# Altium schematic sheet OpenRing_MCU.SchDoc
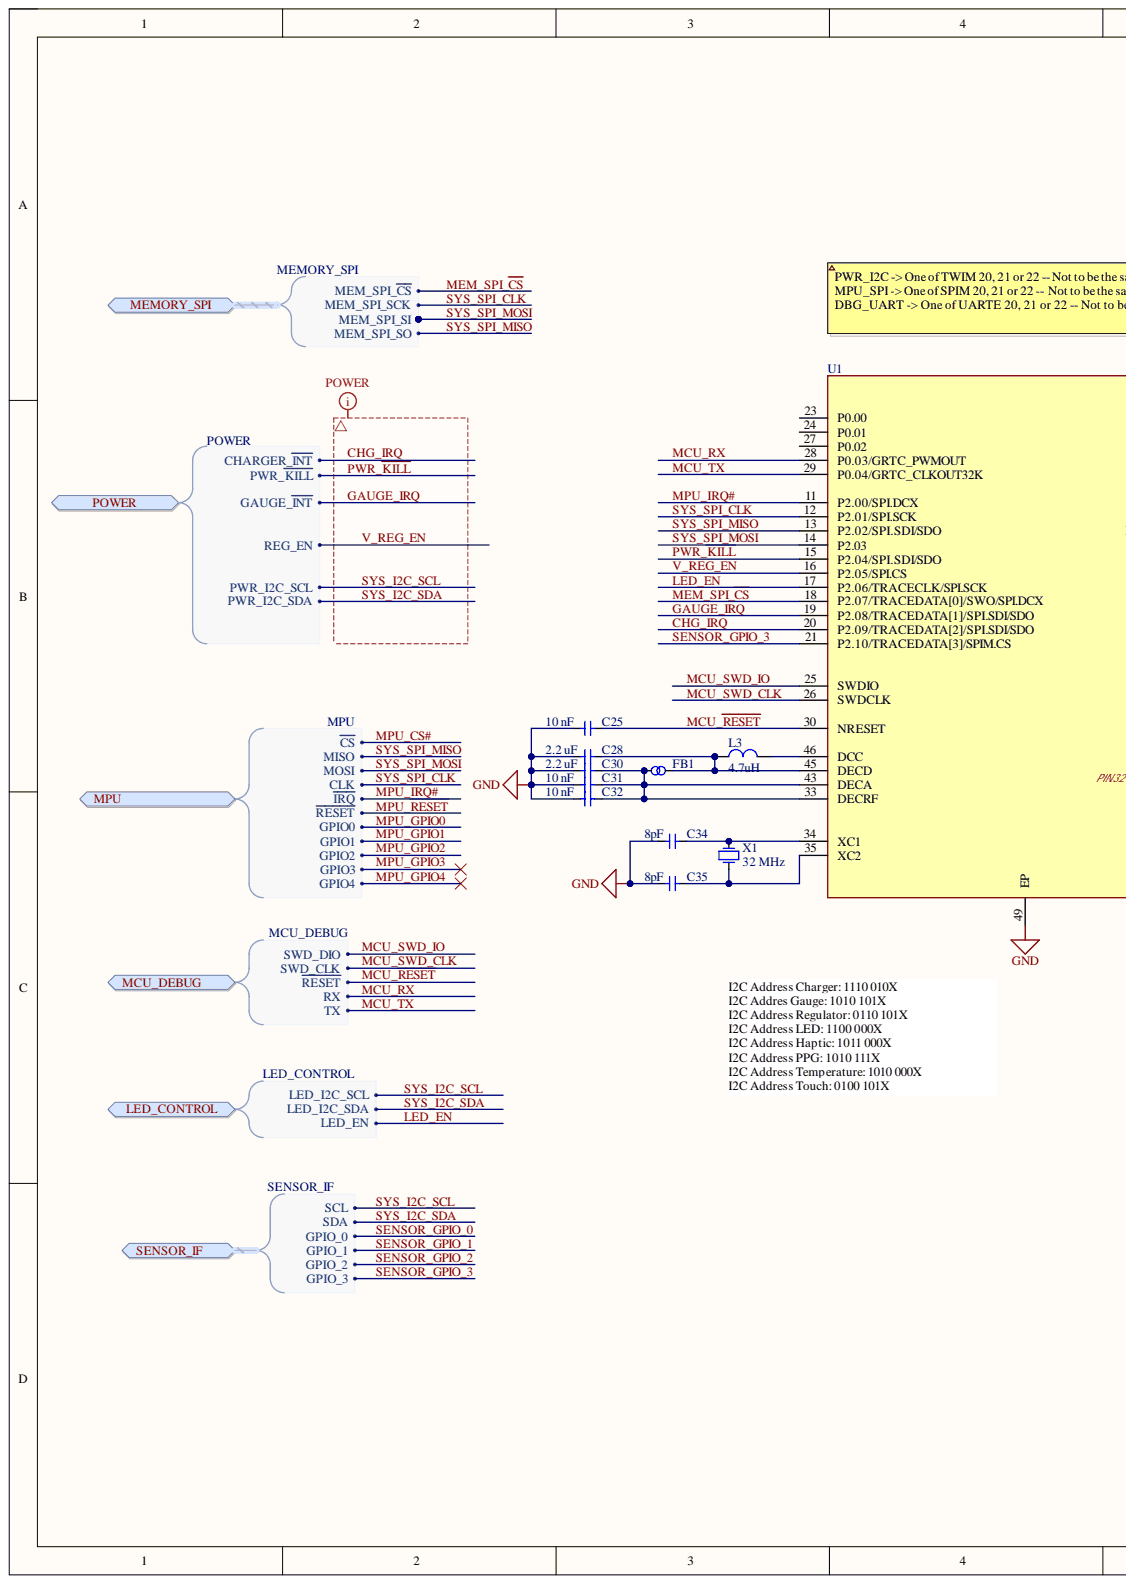
[diagram p0: OpenRing_MCU.SchDoc, left]
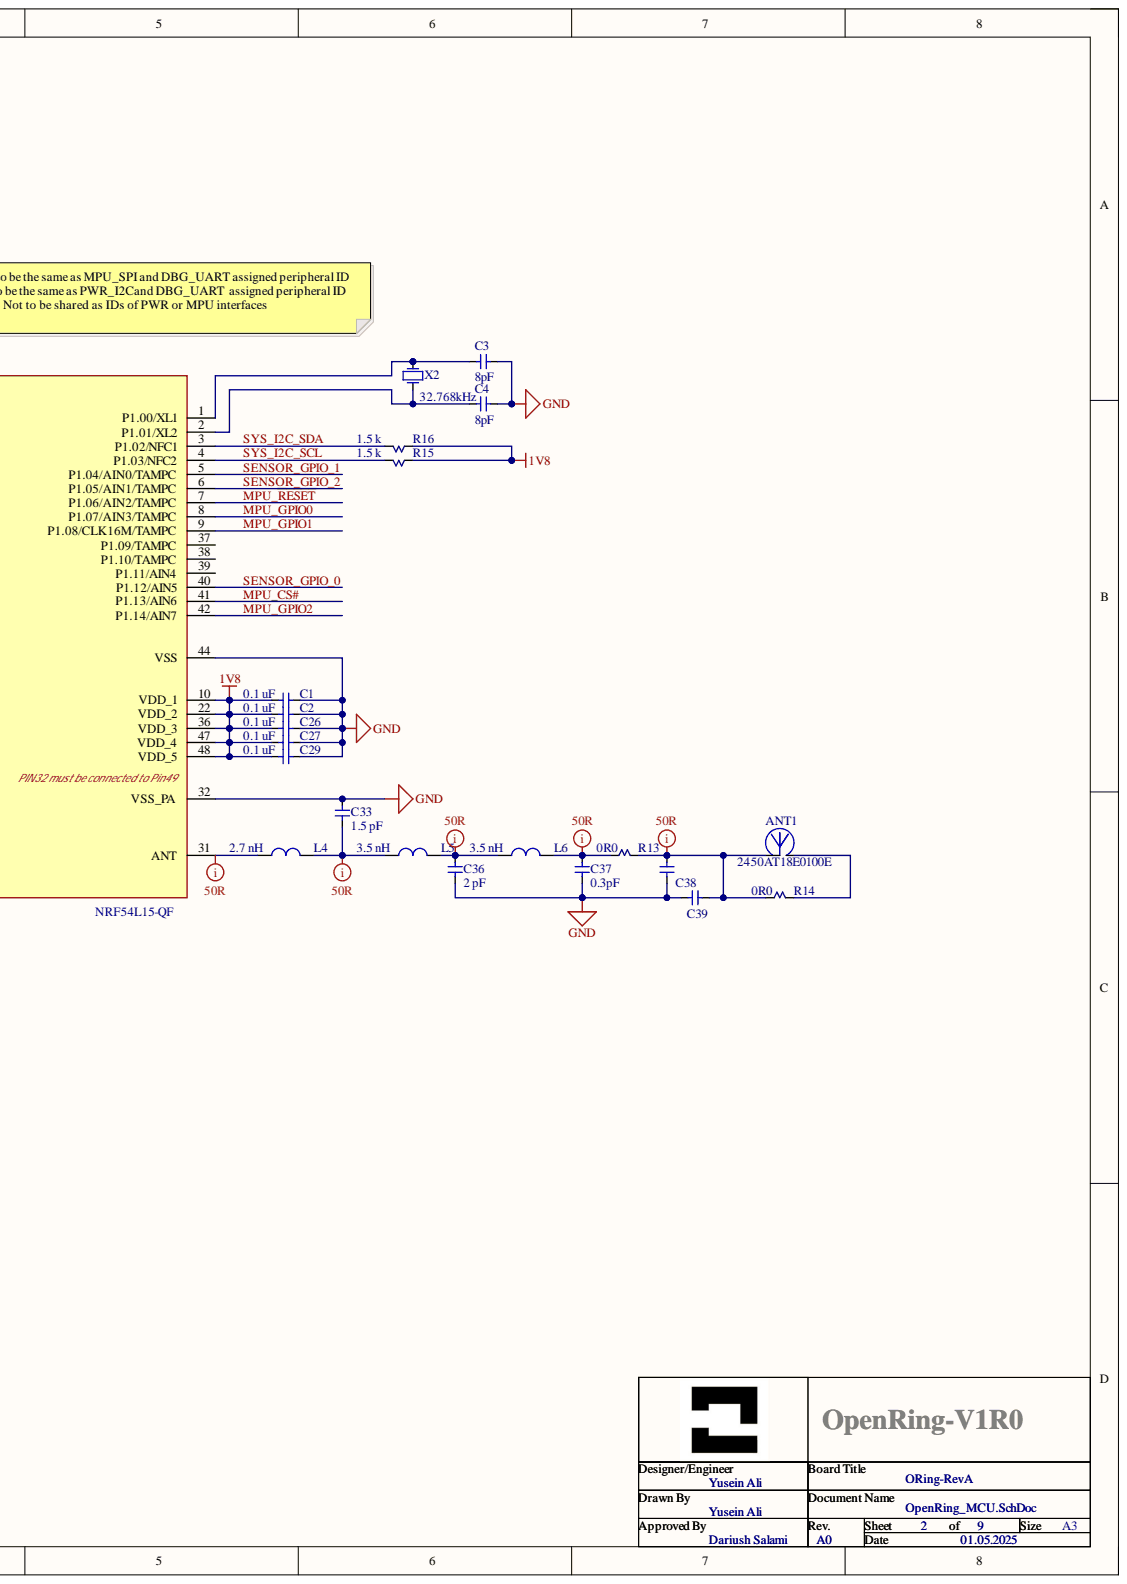
[diagram p1: OpenRing_MCU.SchDoc, right]

## Parts
ANT1  2450AT18E0100E  [ANTC3216X130N]
C1  0.1 uF  [CAPC1005X05N]
C2  0.1 uF  [CAPC1005X05N]
C3  8pF  [CAPC1005X05N]
C4  8pF  [CAPC1005X05N]
C25  10 nF  [CAPC1005X05N]
C26  0.1 uF  [CAPC1005X05N]
C27  0.1 uF  [CAPC1005X05N]
C28  2.2 uF  [CAPC1005X05N]
C29  0.1 uF  [CAPC1005X05N]
C30  2.2 uF  [CAPC1005X05N]
C31  10 nF  [CAPC1005X05N]
C32  10 nF  [CAPC1005X05N]
C33  1.5 pF  [CAPC1005X05N]
C34  8pF  [CAPC1005X05N]
C35  8pF  [CAPC1005X05N]
C36  2 pF  [CAPC1005X05N]
C37  0.3pF  [INDC1005X06N]
C38  NC  [CAPC1005X05N]  (value not drawn)
C39  NC  [CAPC1005X05N]  (value not drawn)
FB1  BLM15AG121SN1D  [INDC1005X06N]  (value not drawn)
L3  4.7uH  [INDC1005X06N]
L4  2.7 nH  [INDC1005X06N]
L5  3.5 nH  [INDC1005X06N]
L6  3.5 nH  [INDC1005X06N]
R13  0R0  [RESC1005X04N]
R14  0R0  [RESC1005X04N]
R15  1.5 k  [RESC1005X04N]
R16  1.5 k  [RESC1005X04N]
U1  NRF54L15-QF  [QFN40P600X600X95-49N]
X1  32 MHz  [FP-ABM11W-MFG]
X2  32.768kHz  [Abracon_ABS6_2012X06L]

## Ports
LED_CONTROL, LED_CONTROL.LED_EN, LED_CONTROL.LED_I2C_SCL, LED_CONTROL.LED_I2C_SDA, MCU_DEBUG, MCU_DEBUG.RX, MCU_DEBUG.SWD_CLK, MCU_DEBUG.SWD_DIO, MCU_DEBUG.TX, MCU_DEBUG.~{RESET}, MEMORY_SPI, MEMORY_SPI.MEM_SPI_SCK, MEMORY_SPI.MEM_SPI_SI, MEMORY_SPI.MEM_SPI_SO, MEMORY_SPI.MEM_SPI_~{CS}, MPU, MPU.CLK, MPU.GPIO0, MPU.GPIO1, MPU.GPIO2, MPU.GPIO3, MPU.GPIO4, MPU.MISO, MPU.MOSI, MPU.~{CS}, MPU.~{IRQ}, MPU.~{RESET}, POWER, POWER.CHARGER_~{INT}, POWER.GAUGE_~{INT}, POWER.PWR_I2C_SCL, POWER.PWR_I2C_SDA, POWER.PWR_~{KILL}, POWER.REG_EN, SENSOR_IF, SENSOR_IF.GPIO_0, SENSOR_IF.GPIO_1, SENSOR_IF.GPIO_2, SENSOR_IF.GPIO_3, SENSOR_IF.SCL, SENSOR_IF.SDA

## Nets
1V8: C1.1 C2.1 C26.1 C27.1 C29.1 R15.1 R16.1 U1.10(VDD_1) U1.22(VDD_2) U1.36(VDD_3) U1.47(VDD_4) U1.48(VDD_5)
GND: C1.2 C2.2 C3.2 C4.1 C25.1 C26.2 C27.2 C28.1 C29.2 C30.1 C31.1 C32.1 C33.2 C34.1 C35.1 C36.1 C37.1 C38.1 C39.2 U1.32(VSS_PA) U1.44(VSS) U1.49(EP)
CHG_IRQ: U1.20(P2.09/TRACEDATA[2]/SPI.SDI/SDO) ; port POWER
GAUGE_IRQ: U1.19(P2.08/TRACEDATA[1]/SPI.SDI/SDO) ; port POWER
LED_EN: U1.17(P2.06/TRACECLK/SPI.SCK) ; port LED_CONTROL
MCU_~{RESET}: C25.2 U1.30(NRESET) ; port MCU_DEBUG
MCU_RX: U1.28(P0.03/GRTC_PWMOUT) ; port MCU_DEBUG
MCU_SWD_CLK: U1.26(SWDCLK) ; port MCU_DEBUG
MCU_SWD_IO: U1.25(SWDIO) ; port MCU_DEBUG
MCU_TX: U1.29(P0.04/GRTC_CLKOUT32K) ; port MCU_DEBUG
MEM_SPI_~{CS}: U1.18(P2.07/TRACEDATA[0]/SWO/SPI.DCX) ; port MEMORY_SPI
MPU_CS#: U1.41(P1.13/AIN6) ; port MPU
MPU_GPIO0: U1.8(P1.07/AIN3/TAMPC) ; port MPU
MPU_GPIO1: U1.9(P1.08/CLK16M/TAMPC) ; port MPU
MPU_GPIO2: U1.42(P1.14/AIN7) ; port MPU
MPU_IRQ#: U1.11(P2.00/SPI.DCX) ; port MPU
MPU_~{RESET}: U1.7(P1.06/AIN2/TAMPC) ; port MPU
PWR_~{KILL}: U1.15(P2.04/SPI.SDI/SDO) ; port POWER
SENSOR_GPIO_0: U1.40(P1.12/AIN5) ; port SENSOR_IF
SENSOR_GPIO_1: U1.5(P1.04/AIN0/TAMPC) ; port SENSOR_IF
SENSOR_GPIO_2: U1.6(P1.05/AIN1/TAMPC) ; port SENSOR_IF
SENSOR_GPIO_3: U1.21(P2.10/TRACEDATA[3]/SPIM.CS) ; port SENSOR_IF
SYS_I2C_SCL: R15.2 U1.4(P1.03/NFC2) ; port LED_CONTROL, port POWER, port SENSOR_IF
SYS_I2C_SDA: R16.2 U1.3(P1.02/NFC1) ; port LED_CONTROL, port POWER, port SENSOR_IF
SYS_SPI_CLK: U1.12(P2.01/SPI.SCK) ; port MEMORY_SPI, port MPU
SYS_SPI_MISO: U1.13(P2.02/SPI.SDI/SDO) ; port MEMORY_SPI, port MPU
SYS_SPI_MOSI: U1.14(P2.03) ; port MEMORY_SPI, port MPU
V_REG_EN: U1.16(P2.05/SPI.CS) ; port POWER
NetANT1_1: ANT1.1 C38.2 C39.1 R13.2 R14.1
NetANT1_2: ANT1.2 R14.2
NetC3_1: C3.1 U1.1(P1.00/XL1) X2.2
NetC4_2: C4.2 U1.2(P1.01/XL2) X2.1
NetC28_2: C28.2 FB1.2 L3.1 U1.45(DECD)
NetC30_2: C30.2 C31.2 C32.2 FB1.1 U1.33(DECRF) U1.43(DECA)
NetC33_1: C33.1 L4.2 L5.1
NetC34_2: C34.2 U1.34(XC1) X1.3
NetC35_2: C35.2 U1.35(XC2) X1.1
NetC36_2: C36.2 L5.2 L6.1
NetC37_2: C37.2 L6.2 R13.1
NetL3_2: L3.2 U1.46(DCC)
NetL4_1: L4.1 U1.31(ANT)
MPU_GPIO3: ; port MPU
MPU_GPIO4: ; port MPU

Not connected: U1 23(P0.00) 24(P0.01) 27(P0.02) 37(P1.09/TAMPC) 38(P1.10/TAMPC) 39(P1.11/AIN4)

## Library data of the parts
ANT1 = 2450AT18E0100E: 7 Inch Reel / Mini 2.45Ghz Chip Antenna; Manufacturer: Johanson; Checked: TRUE; Category: RF Antennas; Component Kind: Standard; ComponentLink1Description: https://datasheet.ciiva.com/24048/antenna-2450at18e0100-253355-24048542.pdf?src-supplier=Mouser; ComponentLink1URL: https://datasheet.ciiva.com/24048/antenna-2450at18e0100-253355-24048542.pdf?src-supplier=Mouser; Library Name: RFComponents.SchLib; Manufacturer Part Number: 2450AT18E0100E; Pricing: 1=0.69, 10=0.624, 50=0.624, 100=0.479, 1000=0.303, 10000=0.245 (USD); RoHS: Yes; Stock: 4431; Supplier: Mouser; Supplier 1: Mouser; Supplier Part Number: 609-2450AT18E0100E; Supplier Part Number 1: 609-2450AT18E0100E
C1 C2 C26 C27 C29 = GRM155R61C104KA88D: CAP CER 0.1UF 16V X5R 0402; Brand: Murata Electronics; Capacitance - nF: 100 nF; Capacitance - pF: 100000 pF; Tolerance: 10 %; Type: Chip Multilayer Ceramic Capacitor for General Purpose; Voltage Rating DC: 16 VDC; Capacitance: 0.1 uF; Case Code - in: 0402; Case Code - mm: 1005; Category: Multilayer Ceramic Capacitors MLCC - SMD/SMT; Component Kind: Standard; ComponentLink1Description: http://www.mouser.com/datasheet/2/281/murata_03052018_GRM_Series_1-1310166.pdf; ComponentLink1URL: http://www.mouser.com/datasheet/2/281/murata_03052018_GRM_Series_1-1310166.pdf; Dielectric: X5R; Height: 0.5 mm; Length: 1 mm; Manufacturer: Murata Electronics; Manufacturer Part Number: GRM155R61C104KA88D; Maximum Operating Temperature: + 85 C; Minimum Operating Temperature: - 55 C; Minimum Order Quantity: 1; Package / Case: 0402 (1005 metric); Packaging: Cut Tape; Pricing: 1=0.082, 10=0.026, 100=0.011, 500=0.008, 1000=0.007, 2500=0.006, 5000=0.005, 10000=0.004 (EUR); Product: General Type MLCCs; Reeling: True; RoHS: RoHS Compliant; RoHS - Mouser: Y; Series: GRM; Standard Pack Qty: 10000; Stock: 0; Supplier 1: Mouser; Supplier 2: Digikey; Supplier 3: Digikey; Supplier Part Number 1: 81-GRM155R61C104KA8D; Supplier Part Number 2: 490-5415-1-ND; Supplier Part Number 3: 490-5415-6-ND; Termination: Standard; Termination Style: SMD/SMT; Value: 0.1 uF; Width: 0.5 mm; Library Name: OpenRingComponents.IntLib
C3 C4 C34 C35 = GRM1555C1H8R0BA01D: Multilayer Ceramic Capacitors MLCC - SMD/SMT 0402 8.0pF 50volts C0G +/-0.05pF; Manufacturer: Murata; Voltage Rating: 50V; Case Code (Imperial): 0402; Case Code (Metric): 1005; Case/Package: 0402; Category: Ceramic Capacitors; Component Kind: Standard; ComponentLink1Description: https://datasheet.ciiva.com/18184/getdatasheetpartid-319021-18184500.pdf?src-supplier=Verical; ComponentLink1URL: https://datasheet.ciiva.com/18184/getdatasheetpartid-319021-18184500.pdf?src-supplier=Verical; ComponentLink2Description: https://datasheet.ciiva.com/6263/grm1555c1h820ga01-01-6263780.pdf?src-supplier=Digi-Key; ComponentLink2URL: https://datasheet.ciiva.com/6263/grm1555c1h820ga01-01-6263780.pdf?src-supplier=Digi-Key; ComponentLink3Description: https://datasheet.ciiva.com/5432/product-5432205.pdf?src-supplier=Mouser; ComponentLink3URL: https://datasheet.ciiva.com/5432/product-5432205.pdf?src-supplier=Mouser; ComponentLink4Description: https://datasheet.ciiva.com/11610/product-11610151.pdf?src-supplier=Avnet; ComponentLink4URL: https://datasheet.ciiva.com/11610/product-11610151.pdf?src-supplier=Avnet; ComponentLink5Description: https://datasheet.ciiva.com/16495/c02e-16495287.pdf?src-supplier=Future+Electronics; ComponentLink5URL: https://datasheet.ciiva.com/16495/c02e-16495287.pdf?src-supplier=Future+Electronics; ComponentLink6Description: https://datasheet.ciiva.com/5442/product-5442458.pdf?src-supplier=Mouser; ComponentLink6URL: https://datasheet.ciiva.com/5442/product-5442458.pdf?src-supplier=Mouser; Construction: Flat; Depth: 0.5mm; Dielectric: C0G; Height: 0.5mm; Lead Free: Lead Free; Length: 1mm; Manufacturer Part Number: GRM1555C1H8R0BA01D; Max Operating Temperature: 125°C; Min Operating Temperature: -55°C; Mounting Technology: Surface Mount; Package Quantity: 1; Packaging: Tape and Reel; Pricing: 1=0,1, 10=0,051, 50=0,0278, 100=0,0227, 500=0,01624, 1000=0,01276, 2500=0,0116, 5000=0,01067 (USD); Radiation Hardening: No; RoHS: Yes; RoHS Compliant: Yes; Series: GRM; Stock: 671988; Supplier: Digi-Key; Supplier 1: Mouser; Supplier 2: Digikey; Supplier 3: Digikey; Supplier Part Number: 490-8230-1-ND; Supplier Part Number 1: 81-GRM1555C1H8R0BA1D; Supplier Part Number 2: 490-8230-1-ND; Supplier Part Number 3: 490-8230-6-ND; Termination: SMD/SMT; Thickness: 0.022inch; Value: 8pF; Voltage Rating (DC): 50V; Width: 0.02inch; Library Name: OpenRingComponents.IntLib
C25 C31 C32 = GRM155R71H103KA88D: CAP CER 10000PF 50V X7R 0402; Manufacturer: Murata Electronics North America; Tolerance: ±10%; Applications: General Purpose; Capacitance: 10000pF; Category: Capacitors; Component Kind: Standard; ComponentLink1Description: http://www.murata.com/~/media/webrenewal/support/library/catalog/products/capacitor/mlcc/c02e.pdf; ComponentLink1URL: http://www.murata.com/~/media/webrenewal/support/library/catalog/products/capacitor/mlcc/c02e.pdf; Features: -; Height - Seated (Max): -; Lead Spacing: -; Lead Style: -; Manufacturer Part Number: GRM155R71H103KA88D; Mounting Type: Surface Mount, MLCC; Operating Temperature: -55°C ~ 125°C; Package / Case: 0402 (1005 Metric); Packaging: Digi-Reel®; Part Status: Active; Pricing 1: 1=0.11, 50=0.0056, 100=0.0047, 250=0.00384, 500=0.0033, 1000=0.00256, 5000=0.00213 (GBP); Ratings: -; RoHS: RoHS Compliant; Signal Integrity: Model Name; Size / Dimension: 0.039" L x 0.020" W (1.00mm x 0.50mm); Stock 1: 7217649; Supplier 1: Digi-Key; Supplier Part Number 1: 490-4516-6-ND; Temperature Coefficient: X7R; Thickness (Max): 0.022" (0.55mm); Value: 10 nF; Voltage - Rated: 50V; Library Name: OpenRingComponents.IntLib
C28 C30 = GRM155R61A225KE95D: CAP CER 2.2UF 10V X5R 0402; Manufacturer: Murata Electronics North America; Capacitance: 2.2µF; Tolerance: ±10%; Applications: General Purpose; Category: Capacitors; Component Kind: Standard; ComponentLink1Description: http://www.murata.com/~/media/webrenewal/support/library/catalog/products/capacitor/mlcc/c02e.ashx; ComponentLink1URL: http://www.murata.com/~/media/webrenewal/support/library/catalog/products/capacitor/mlcc/c02e.ashx; Features: Derating Recommended; Height - Seated (Max): -; Lead Spacing: -; Lead Style: -; Manufacturer Part Number: GRM155R61A225KE95D; Mounting Type: Surface Mount, MLCC; Operating Temperature: -55°C ~ 85°C; Package / Case: 0402 (1005 Metric); Packaging: Digi-Reel®; Part Status: Active; Pricing 1: 1=0.1, 10=0.06, 50=0.0326, 100=0.0275, 250=0.02252, 500=0.01926, 1000=0.015, 5000=0.0125 (USD); Ratings: -; RoHS: RoHS Compliant; Signal Integrity: Model Name; Size / Dimension: 0.039" L x 0.020" W (1.00mm x 0.50mm); Stock 1: 370562; Supplier 1: Digi-Key; Supplier Part Number 1: 490-10451-6-ND; Temperature Coefficient: X5R; Thickness (Max): 0.022" (0.55mm); Value: 2.2 uF; Voltage - Rated: 10V; Library Name: OpenRingComponents.IntLib
C33 = GJM1555C1H1R5BB01D: Multilayer Ceramic Capacitor, 1.5 pF, 50 V, ï¿½ 0.1pF, C0G (NP0), 0402 [1005 Metric]; Manufacturer: Murata; Material: 4241ConductorMaterial; Category: Ceramic Capacitors; Component Kind: Standard; ComponentLink1Description: https://datasheet.ciiva.com/8030/1700191-8030107.pdf?src-supplier=Element14; ComponentLink1URL: https://datasheet.ciiva.com/8030/1700191-8030107.pdf?src-supplier=Element14; ComponentLink2Description: https://datasheet.ciiva.com/26843/getdatasheetpartid-698463-26843693.pdf?src-supplier=Verical; ComponentLink2URL: https://datasheet.ciiva.com/26843/getdatasheetpartid-698463-26843693.pdf?src-supplier=Verical; ComponentLink3Description: https://datasheet.ciiva.com/29542/partnumbering-e-01-29542725.pdf?src-supplier=Digikey; ComponentLink3URL: https://datasheet.ciiva.com/29542/partnumbering-e-01-29542725.pdf?src-supplier=Digikey; ComponentLink4Description: https://datasheet.ciiva.com/29542/partnumbering-e-01-29542726.pdf?src-supplier=Digikey; ComponentLink4URL: https://datasheet.ciiva.com/29542/partnumbering-e-01-29542726.pdf?src-supplier=Digikey; ComponentLink5Description: https://datasheet.ciiva.com/16440/l0005e-16440473.pdf?src-supplier=Future+Electronics; ComponentLink5URL: https://datasheet.ciiva.com/16440/l0005e-16440473.pdf?src-supplier=Future+Electronics; Lead Free: 5697LeadFree; Manufacturer Part Number: GJM1555C1H1R5BB01D; Pricing: 1=0.1, 10=0.05, 50=0.05, 100=0.03, 1000=0.022, 10000=0.011 (USD); RoHS: Yes; Stock: 9670; Supplier: Mouser; Supplier 1: Mouser; Supplier 2: Digikey; Supplier 3: Digikey; Supplier Part Number: 81-GJM1555C1H1R5BB1D; Supplier Part Number 1: 81-GJM1555C1H1R5BB1D; Supplier Part Number 2: 490-8087-1-ND; Supplier Part Number 3: 490-8087-6-ND; Value: 1.5 pF; Library Name: OpenRingComponents.IntLib
C36 = GJM1555C1H2R0WB01D: 0402 2 pF 50V ±0.05 pF Tolerance C0G SMT Multilayer Ceramic Capacitor; Manufacturer: Murata; Material: 4241ConductorMaterial; Temperature Coefficient: 30ppm/°C; Category: Ceramic Capacitors; Component Kind: Standard; ComponentLink1Description: https://datasheet.ciiva.com/26843/getdatasheetpartid-724043-26843695.pdf?src-supplier=Verical; ComponentLink1URL: https://datasheet.ciiva.com/26843/getdatasheetpartid-724043-26843695.pdf?src-supplier=Verical; Lead Free: 5697LeadFree; Library Name: Passives.SchLib; Manufacturer Part Number: GJM1555C1H2R0WB01D; Pricing: 1=0.14, 10=0.077, 50=0.077, 100=0.047, 1000=0.032, 10000=0.019 (USD); RoHS: Yes; Stock: 97187; Supplier: Mouser; Supplier 1: Mouser; Supplier 2: Digikey; Supplier 3: Digikey; Supplier Part Number: 81-GJM1555C1H2R0WB1D; Supplier Part Number 1: 81-GJM1555C1H2R0WB1D; Supplier Part Number 2: 490-11205-1-ND; Supplier Part Number 3: 490-11205-6-ND; Value: 2 pF
C37 = GJM1555C1HR30WB01D: 0402 0.3 pF 50V ±0.05 pF Tolerance C0G SMT Multilayer Ceramic Capacitor; Manufacturer: Murata; Material: 4241ConductorMaterial; Temperature Coefficient: 30ppm/°C; Case Code (Imperial): 0402; Case Code (Metric): 1005; Case/Package: 0402; Category: Ceramic Capacitors; Component Kind: Standard; ComponentLink1Description: https://datasheet.ciiva.com/11617/product-11617574.pdf?src-supplier=Avnet; ComponentLink1URL: https://datasheet.ciiva.com/11617/product-11617574.pdf?src-supplier=Avnet; ComponentLink2Description: https://datasheet.ciiva.com/169/partnumbering-e-01-169995.pdf?src-supplier=Digikey; ComponentLink2URL: https://datasheet.ciiva.com/169/partnumbering-e-01-169995.pdf?src-supplier=Digikey; Construction: Flat; Depth: 0.5mm; Dielectric: C0G; Height: 0.5mm; Lead Free: Lead Free; Length: 1mm; Manufacturer Part Number: GJM1555C1HR30WB01D; Max Operating Temperature: 125°C; Min Operating Temperature: -55°C; Mounting Technology: Surface Mount; Package Quantity: 10000; Packaging: Tape and Reel; Pricing: 1=0.14, 10=0.077, 50=0.077, 100=0.047, 1000=0.031, 10000=0.021 (USD); Radiation Hardening: No; RoHS: Yes; RoHS Compliant: Yes; Series: GJM; Stock: 24305; Supplier: Mouser; Supplier 1: Mouser; Supplier 2: Digikey; Supplier 3: Digikey; Supplier Part Number: 81-GJM1555C1HR30WB1D; Supplier Part Number 1: 81-GJM1555C1HR30WB1D; Supplier Part Number 2: 490-11248-1-ND; Supplier Part Number 3: 490-11248-6-ND; Termination: SMD/SMT; Thickness: 0.022inch; Value: 0.3pF; Voltage Rating: 50V; Voltage Rating (DC): 50V; Width: 0.02inch; Library Name: OpenRingComponents.IntLib
C38 C39 = CAPACITOR: Component Kind: Standard; Library Name: OpenRingComponents.IntLib
FB1 = BLM15AG121SN1D: Ferrite Beads Multi-Layer 120Ohm 25% 100MHz 550mA 190mOhm DCR 0402 Paper T/R; Manufacturer: Murata; Manufacturer Series: BLM15A; Channels: 1; DC Current: 500mA; DC Resistance (DCR): 190mR; DC Resistance-Max: 250mR; Impedance: 120R; Material: Steel,Ferrite; Shielding: Unshielded; Case Code (Imperial): 0402; Case Code (Metric): 1005; Case/Package: 0402; Category: Ferrite Beads and Chips; Component Kind: Standard; Core Material: Ferrite; Current Rating: 550mA; Depth: 0.55mm; ESR: 190mR; Frequency: 100MHz; Height: 0.5mm; Lead Free: Lead Free; Length: 1mm; Manufacturer Part Number: BLM15AG121SN1D; Max Current Rating: 550mA; Max DC Current: 500mA; Max Operating Temperature: 125°C; Military Standard: Not; Min Operating Temperature: -55°C; Number of Circuits: 1; Number of Lines: 1; Package Quantity: 1; Packaging: Tape and Reel; Pins: 2; Pricing: 1=0.1, 10=0.043, 50=0.034, 100=0.031, 1000=0.021, 10000=0.013 (USD); Radiation Hardening: No; Reach SVHC Compliant: No; RMS Current (Irms): 550mA; RoHS: Yes; RoHS Compliant: Yes; Series: BLM15AG_SN; Stock: 120691; Supplier: Mouser; Supplier 1: Mouser; Supplier 2: Digikey; Supplier 3: Digikey; Supplier Part Number: 81-BLM15AG121SN1D; Supplier Part Number 1: 81-BLM15AG121SN1D; Supplier Part Number 2: 490-1005-1-ND; Supplier Part Number 3: 490-1005-6-ND; Terminal Finish: Tin; Termination: SMD/SMT; Test Frequency: 100MHz; Tolerance: 25%; Width: 0.5mm; Library Name: OpenRingComponents.IntLib
L3 = LQM18FN4R7M00D: Inductor Power Chip Shielded Multi-Layer 4.7uH 20% 1MHz Ferrite 0.08A 0.78Ohm DCR 0603 T/R; Manufacturer: Murata; Current Rating: 80mA; DC Resistance (DCR): 600mR; Self Resonant Frequency: 50MHz; Case Code (Imperial): 0603; Case Code (Metric): 1608; Case/Package: 0603; Category: Fixed Inductors; Component Kind: Standard; ComponentLink1Description: https://datasheet.ciiva.com/30489/0900766b812560c4-30489024.pdf?src-supplier=Rs; ComponentLink1URL: https://datasheet.ciiva.com/30489/0900766b812560c4-30489024.pdf?src-supplier=Rs; ComponentLink2Description: https://datasheet.ciiva.com/1039/l0075s0097lqm18f-1039394.pdf?src-supplier=Digikey; ComponentLink2URL: https://datasheet.ciiva.com/1039/l0075s0097lqm18f-1039394.pdf?src-supplier=Digikey; ComponentLink3Description: https://datasheet.ciiva.com/1039/l0075s0097lqm18f-1039393.pdf?src-supplier=Digikey; ComponentLink3URL: https://datasheet.ciiva.com/1039/l0075s0097lqm18f-1039393.pdf?src-supplier=Digikey; ComponentLink4Description: https://datasheet.ciiva.com/1039/l0075s0097lqm18f-1039395.pdf?src-supplier=Digikey; ComponentLink4URL: https://datasheet.ciiva.com/1039/l0075s0097lqm18f-1039395.pdf?src-supplier=Digikey; ComponentLink5Description: https://datasheet.ciiva.com/3082/l0075s0097lqm18f-3082197.pdf?src-supplier=Avnet; ComponentLink5URL: https://datasheet.ciiva.com/3082/l0075s0097lqm18f-3082197.pdf?src-supplier=Avnet; ComponentLink6Description: https://datasheet.ciiva.com/16390/l0075s0097lqm18f-16390346.pdf?src-supplier=Future+Electronics; ComponentLink6URL: https://datasheet.ciiva.com/16390/l0075s0097lqm18f-16390346.pdf?src-supplier=Future+Electronics; Core Material: Ferrite; DC Current: 80mA; Depth: 0.9mm; Height: 0.8mm; Height - Seated (Max): 0.035inch; Lead Free: Lead Free; Length: 1.6mm; Library Name: Passives.SchLib; Manufacturer Part Number: LQM18FN4R7M00D; Max Current Rating: 80mA; Max DC Current: 80mA; Max Operating Temperature: 125°C; Military Standard: Not; Min Operating Temperature: -55°C; Mounting Technology: Surface Mount; Package Quantity: 1; Packaging: Tape and Reel; Pricing: 1=0.13, 10=0.11, 50=0.11, 100=0.091, 1000=0.075, 10000=0.06 (USD); RoHS: Yes; RoHS Compliant: Yes; Saturation Current: 80mA; Series: LQM18F; Shielding: Shielded; Stock: 677; Supplier: Mouser; Supplier 1: Mouser; Supplier 2: Digikey; Supplier 3: Digikey; Supplier Part Number: 81-LQM18FN4R7M00D; Supplier Part Number 1: 81-LQM18FN4R7M00D; Supplier Part Number 2: 490-4024-1-ND; Supplier Part Number 3: 490-4024-6-ND; Termination: SMD/SMT; Test Frequency: 1MHz; Tolerance: 20%; Value: 4.7uH; Weight: 7.1E-05oz; Width: 0.031inch
L4 = LQG15WH2N7B02D: Inductor Chip 2.7nH 0.1nH Tolerance 2-Pin 0402 Paper T/R; Manufacturer: Murata; Category: Fixed Inductors; Component Kind: Standard; Manufacturer Part Number: LQG15WH2N7B02D; Pricing: 1=0.2, 10=0.161, 50=0.141, 100=0.133, 1000=0.109, 10000=0.088 (USD); RoHS: Yes; Stock: 6000; Supplier: Mouser; Supplier 1: Mouser; Supplier 2: Digikey; Supplier 3: Digikey; Supplier Part Number: 81-LQG15WH2N7B02D; Supplier Part Number 1: 81-LQG15WH2N7B02D; Supplier Part Number 2: 490-LQG15WH2N7B02DDKR-ND; Supplier Part Number 3: 490-LQG15WH2N7B02DCT-ND; Value: 2.7 nH; Library Name: OpenRingComponents.IntLib
L5 L6 = LQG15WH3N5B02D: Inductor Chip 3.5nH 0.1nH Tolerance 2-Pin 0402 Paper T/R; Manufacturer: Murata; Category: Fixed Inductors; Component Kind: Standard; Manufacturer Part Number: LQG15WH3N5B02D; Pricing: 1=0.24, 10=0.187, 50=0.187, 100=0.146, 1000=0.113, 10000=0.09 (USD); RoHS: Yes; Stock: 9594; Supplier: Mouser; Supplier 1: Mouser; Supplier 2: Farnell; Supplier 3: Farnell; Supplier Part Number: 81-LQG15WH3N5B02D; Supplier Part Number 1: 81-LQG15WH3N5B02D; Supplier Part Number 2: 3639288; Supplier Part Number 3: 3639288RL; Value: 3.5 nH; Library Name: OpenRingComponents.IntLib
R13 R14 = ERJ-2GE0R00X: RES SMD 0.0OHM JUMPER 1/10W 0402; Manufacturer: Panasonic Electronic Components; Power (Watts): 0.1W, 1/10W; Resistance (Ohms): 0.0; Category: Resistors; Component Kind: Standard; ComponentLink1Description: http://industrial.panasonic.com/www-cgi/jvcr13pz.cgi?E+PZ+3+AOA0001+ERJ2GE0R00X+7+WW; ComponentLink1URL: http://industrial.panasonic.com/www-cgi/jvcr13pz.cgi?E+PZ+3+AOA0001+ERJ2GE0R00X+7+WW; Composition: Thick Film; Features: Automotive AEC-Q200; Height: 0.016" (0.40mm); Manufacturer Part Number: ERJ-2GE0R00X; Number of Terminations: 2; Operating Temperature: -55°C ~ 155°C; Package / Case: 0402 (1005 Metric); Packaging: Digi-Reel®; Part Status: Active; Pricing 1: 1=0.07, 50=0.0104, 100=0.0078, 250=0.00584, 500=0.0043, 1000=0.00287, 5000=0.00202 (GBP); RoHS: RoHS Compliant; Signal Integrity: Model Name; Size / Dimension: 0.039" L x 0.020" W (1.00mm x 0.50mm); Stock 1: 6022701; Supplier 1: Digi-Key; Supplier Device Package: 0402; Supplier Part Number 1: P0.0JDKR-ND; Temperature Coefficient: -; Tolerance: Jumper; Value: 0R0; Library Name: OpenRingComponents.IntLib
R15 R16 = ERJ-2RKF1501X: RES SMD 1.5K OHM 1% 1/10W 0402; Manufacturer: Panasonic Electronic Components; Power (Watts): 0.1W, 1/10W; Temperature Coefficient: ±100ppm/°C; Category: Resistors; Component Kind: Standard; ComponentLink1Description: https://industrial.panasonic.com/cdbs/www-data/pdf/RDA0000/AOA0000C283.pdf; ComponentLink1URL: https://industrial.panasonic.com/cdbs/www-data/pdf/RDA0000/AOA0000C283.pdf; Composition: Thick Film; Failure Rate: -; Features: Automotive AEC-Q200; Height - Seated (Max): 0.016" (0.40mm); Manufacturer Part Number: ERJ-2RKF1501X; Number of Terminations: 2; Operating Temperature: -55°C ~ 155°C; Package / Case: 0402 (1005 Metric); Packaging: Digi-Reel®; Part Status: Active; Pricing 1: 1=0.1, 10=0.025, 25=0.018, 50=0.0136, 100=0.01, 250=0.00764, 500=0.00612, 1000=0.00451, 5000=0.00323 (USD); Resistance (Ohms): 1.5k; RoHS: RoHS Compliant; Signal Integrity: Model Name; Size / Dimension: 0.039" L x 0.020" W (1.00mm x 0.50mm); Stock 1: 1349197; Supplier 1: Digi-Key; Supplier Device Package: 0402; Supplier Part Number 1: P1.50KLDKR-ND; Tolerance: ±1%; Value: 1.5 k; Library Name: OpenRingComponents.IntLib
U1 = NRF54L15-QFAA-R: nRF54L15 SoC with Arm Cortex-M33 processor; Manufacturer: Nordic Semiconductor; Category: RF Receivers, Transceivers; Component Kind: Standard; Manufacturer Part Number: NRF54L15-QFAA-R; Pricing: 1=6.84, 10=6.11, 50=6.11, 100=5.02, 1000=3.62, 10000=3.07 (USD); RoHS: No; Stock: 719; Supplier: Mouser; Supplier Part Number: 949-NRF54L15-QFAA-R; Library Name: OpenRingComponents.IntLib
X1 = ABM11W-32.0000MHZ-8-B1U-T3: CRYSTAL 32.0000MHZ 8PF SMD, 2x1.6mm, RoHS; Manufacturer: Abracon; Checked: TRUE; Category: Crystals; Component Kind: Standard; ComponentLink1Description: https://abracon.com/Resonators/ABM11W.pdf?src-supplier=Digikey; ComponentLink1URL: https://abracon.com/Resonators/ABM11W.pdf?src-supplier=Digikey; ComponentLink2Description: https://datasheet.octopart.com/ABM11W-32.0000MHZ-8-B1U-T3-Abracon-datasheet-112015802.pdf?src-supplier=Master+Electronics; ComponentLink2URL: https://datasheet.octopart.com/ABM11W-32.0000MHZ-8-B1U-T3-Abracon-datasheet-112015802.pdf?src-supplier=Master+Electronics; Frequency: 32 MHz; Library Name: Passives.SchLib; Manufacturer Part Number: ABM11W-32.0000MHZ-8-B1U-T3; Pricing: 1=0.82, 10=0.709, 50=0.6394, 100=0.6115, 500=0.5517, 1000=0.52788 (USD); RoHS: Yes; Stock: 52771; Supplier: Digikey; Supplier 1: Mouser; Supplier 2: Digikey; Supplier 3: Digikey; Supplier 4: Digikey; Supplier Part Number: 535-13899-1-ND; Supplier Part Number 1: 815-11W32-8B1UT; Supplier Part Number 2: 535-13899-1-ND; Supplier Part Number 3: 535-13899-6-ND; Supplier Part Number 4: 535-13899-2-ND
X2 = ABS06-32.768KHZ-1-T: CRYSTAL 32.768 KHZ 12.5 PF SMD; Manufacturer: Abracon Corporation; ESR (Equivalent Series Resistance): 90 kOhm; Frequency Tolerance: ±10ppm; Load Capacitance: 12.5pF; Operating Mode: Fundamental; Category: Crystals and Oscillators; Component Kind: Standard; ComponentLink1Description: http://www.abracon.com/Resonators/ABS06.pdf; ComponentLink1URL: http://www.abracon.com/Resonators/ABS06.pdf; Frequency: 32.768kHz; Frequency Stability: -; Height: 0.024" (0.60mm); Manufacturer Part Number: ABS06-32.768KHZ-1-T; Mounting Type: Surface Mount; Operating Temperature: -40°C ~ 85°C; Package / Case: 2-SMD; Packaging: Digi-Reel®; Pricing 1: 1=2.25, 10=1.952, 50=1.711, 100=1.3508, 500=1.14066, 750=0.99659, 1000=0.90053 (EUR); Ratings: -; RoHS: RoHS Compliant; Size / Dimension: 0.079" L x 0.047" W (2.00mm x 1.20mm); Stock 1: 6467; Supplier 1: Digi-Key; Supplier Part Number 1: 535-10248-6-ND; Type: kHz Crystal (Tuning Fork); Library Name: OpenRingComponents.IntLib
